# Altium schematic sheet HSMC_V0_2.SchDoc(HSMC_V0_2)
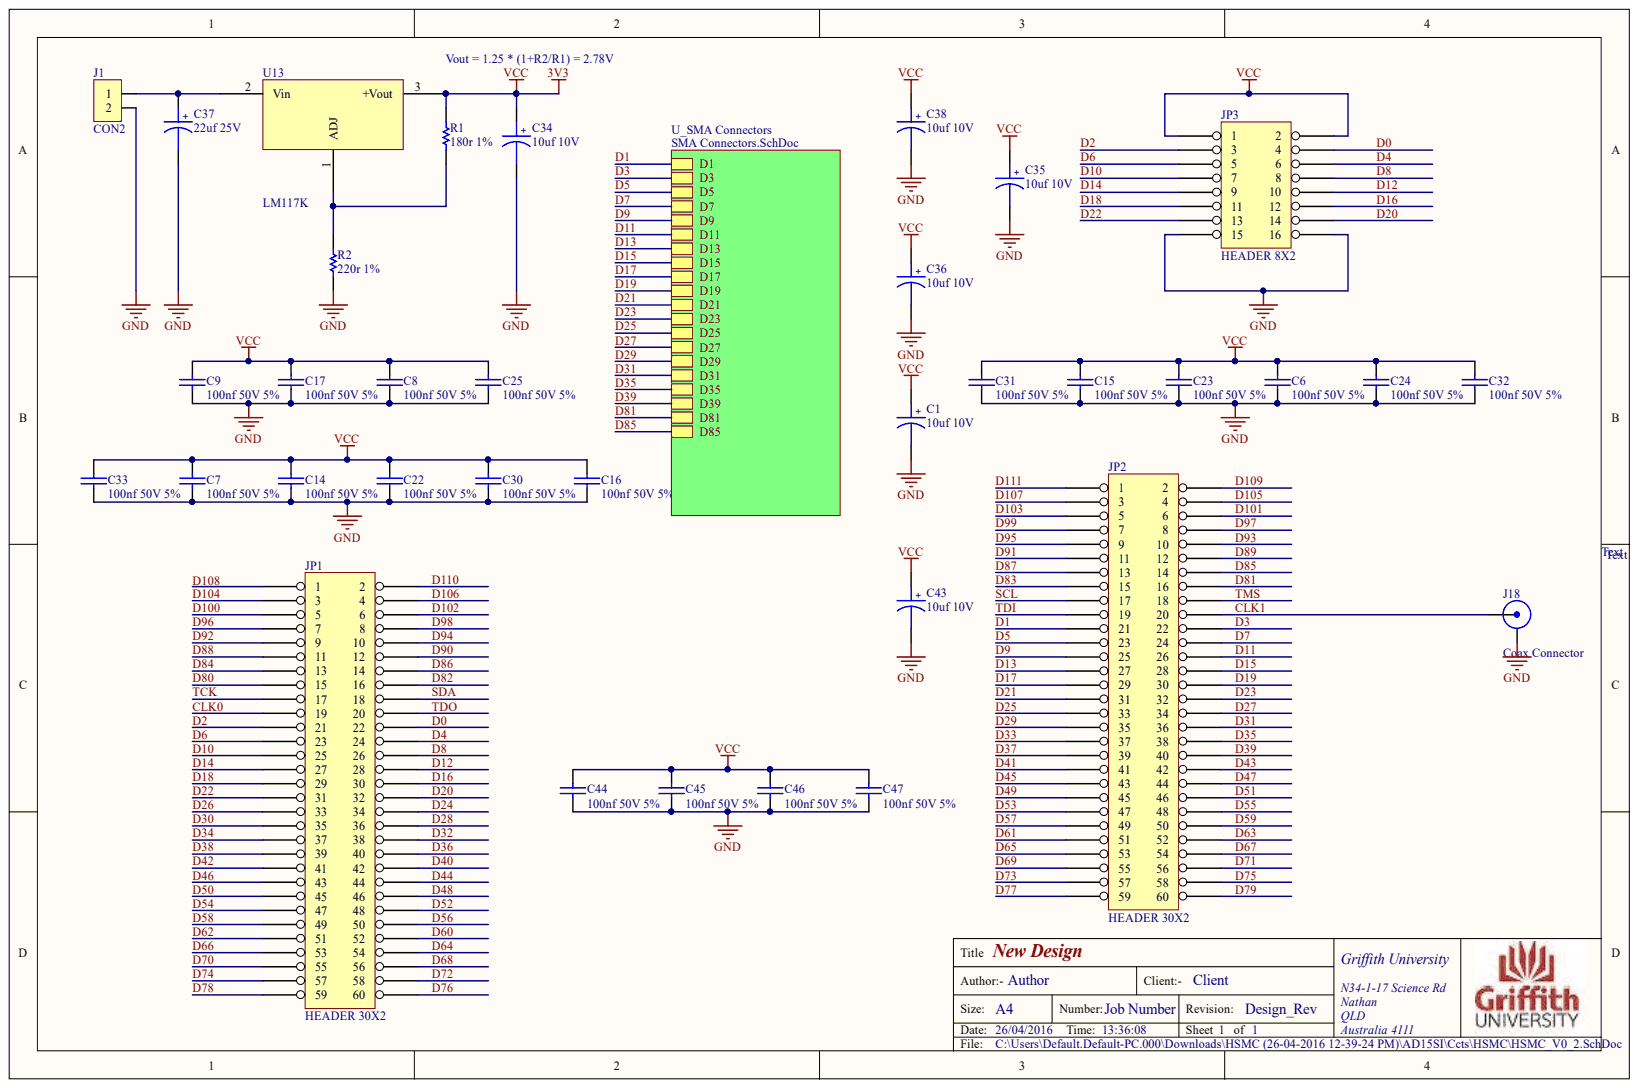
[diagram p0: HSMC_V0_2.SchDoc]

## Parts
C1  10uf 10V  [TAJ-B]
C6  100nf 50V 5%  [0805B_CAP]
C7  100nf 50V 5%  [0805B_CAP]
C8  100nf 50V 5%  [0805B_CAP]
C9  100nf 50V 5%  [0805B_CAP]
C14  100nf 50V 5%  [0805B_CAP]
C15  100nf 50V 5%  [0805B_CAP]
C16  100nf 50V 5%  [0805B_CAP]
C17  100nf 50V 5%  [0805B_CAP]
C22  100nf 50V 5%  [0805B_CAP]
C23  100nf 50V 5%  [0805B_CAP]
C24  100nf 50V 5%  [0805B_CAP]
C25  100nf 50V 5%  [0805B_CAP]
C30  100nf 50V 5%  [0805B_CAP]
C31  100nf 50V 5%  [0805B_CAP]
C32  100nf 50V 5%  [0805B_CAP]
C33  100nf 50V 5%  [0805B_CAP]
C34  10uf 10V  [TAJ-B]
C35  10uf 10V  [TAJ-B]
C36  10uf 10V  [TAJ-B]
C37  22uf 25V  [TAJ-C]
C38  10uf 10V  [TAJ-B]
C43  10uf 10V  [TAJ-B]
C44  100nf 50V 5%  [0805B_CAP]
C45  100nf 50V 5%  [0805B_CAP]
C46  100nf 50V 5%  [0805B_CAP]
C47  100nf 50V 5%  [0805B_CAP]
J1  CON2  [SIP2LP]
J18  Coax Connector  [RF_SMA]
JP1  HEADER 30X2  [IDC60]
JP2  HEADER 30X2  [IDC60]
JP3  HEADER 8X2  [HEADER_8_2 Shroud]
R1  180r 1%  [0805B]
R2  220r 1%  [0805B]
U13  LM117K  [DPAK 3Pin Reg TO-252]

## Nets
3V3: C1.1 C6.1 C7.1 C8.1 C9.1 C14.1 C15.1 C16.1 C17.1 C22.1 C23.1 C24.1 C25.1 C30.1 C31.1 C32.1 C33.1 C34.1 C35.1 C36.1 C38.1 C43.1 C44.1 C45.1 C46.1 C47.1 JP3.1 JP3.2 R1.2 U13.3
GND: C1.2 C6.2 C7.2 C8.2 C9.2 C14.2 C15.2 C16.2 C17.2 C22.2 C23.2 C24.2 C25.2 C30.2 C31.2 C32.2 C33.2 C34.2 C35.2 C36.2 C37.2 C38.2 C43.2 C44.2 C45.2 C46.2 C47.2 J1.2 J18.2 JP3.15 JP3.16 R2.1
CLK0: JP1.19
CLK1: J18.1 JP2.20
D0: JP1.22 JP3.4
D1: JP2.21
D2: JP1.21 JP3.3
D3: JP2.22
D4: JP1.24 JP3.6
D5: JP2.23
D6: JP1.23 JP3.5
D7: JP2.24
D8: JP1.26 JP3.8
D9: JP2.25
D10: JP1.25 JP3.7
D11: JP2.26
D12: JP1.28 JP3.10
D13: JP2.27
D14: JP1.27 JP3.9
D15: JP2.28
D16: JP1.30 JP3.12
D17: JP2.29
D18: JP1.29 JP3.11
D19: JP2.30
D20: JP1.32 JP3.14
D21: JP2.31
D22: JP1.31 JP3.13
D23: JP2.32
D24: JP1.34
D25: JP2.33
D26: JP1.33
D27: JP2.34
D28: JP1.36
D29: JP2.35
D30: JP1.35
D31: JP2.36
D32: JP1.38
D33: JP2.37
D34: JP1.37
D35: JP2.38
D36: JP1.40
D37: JP2.39
D38: JP1.39
D39: JP2.40
D40: JP1.42
D41: JP2.41
D42: JP1.41
D43: JP2.42
D44: JP1.44
D45: JP2.43
D46: JP1.43
D47: JP2.44
D48: JP1.46
D49: JP2.45
D50: JP1.45
D51: JP2.46
D52: JP1.48
D53: JP2.47
D54: JP1.47
D55: JP2.48
D56: JP1.50
D57: JP2.49
D58: JP1.49
D59: JP2.50
D60: JP1.52
D61: JP2.51
D62: JP1.51
D63: JP2.52
D64: JP1.54
D65: JP2.53
D66: JP1.53
D67: JP2.54
D68: JP1.56
D69: JP2.55
D70: JP1.55
D71: JP2.56
D72: JP1.58
D73: JP2.57
D74: JP1.57
D75: JP2.58
D76: JP1.60
D77: JP2.59
D78: JP1.59
D79: JP2.60
D80: JP1.15
D81: JP2.16
D82: JP1.16
D83: JP2.15
D84: JP1.13
D85: JP2.14
D86: JP1.14
D87: JP2.13
D88: JP1.11
D89: JP2.12
D90: JP1.12
D91: JP2.11
D92: JP1.9
D93: JP2.10
D94: JP1.10
D95: JP2.9
D96: JP1.7
D97: JP2.8
D98: JP1.8
D99: JP2.7
D100: JP1.5
D101: JP2.6
D102: JP1.6
D103: JP2.5
D104: JP1.3
D105: JP2.4
D106: JP1.4
D107: JP2.3
D109: JP2.2
D110: JP1.2
D111: JP2.1
SCL: JP2.17
SDA: JP1.18
TCK: JP1.17
TDI: JP2.19
TDO: JP1.20
TMS: JP2.18
NetC37_1: C37.1 J1.1 U13.2
NetJP1_1: JP1.1
NetR1_1: R1.1 R2.2 U13.1
D108:

## Library data of the parts
C1 C34-C38 C43 = CAPACITOR POL: Component Kind: Standard; Library Name: Device.SCHLIB
C6-C9 C14-C17 C22-C25 C30-C33 C44-C47 = CAP: Component Kind: Standard; Library Name: Device.SCHLIB
J18 = BNC: Component Kind: Standard; Description: Coax Connector; Library Name: Device.SCHLIB
R1 R2 = RES: Component Kind: Standard; Library Name: Device.SCHLIB
U13 = LM317K: Component Kind: Standard; Library Name: D_voltre.SCHLIB
J1 = CON2: Component Kind: Standard; Library Name: Device.SCHLIB
JP1 JP2 = HEADER 30X2: Component Kind: Standard; Library Name: Device.SCHLIB
JP3 = HEADER 8X2: Component Kind: Standard; Library Name: Device.SCHLIB
